# Altium schematic sheet Connectors.SchDoc(Connectors)
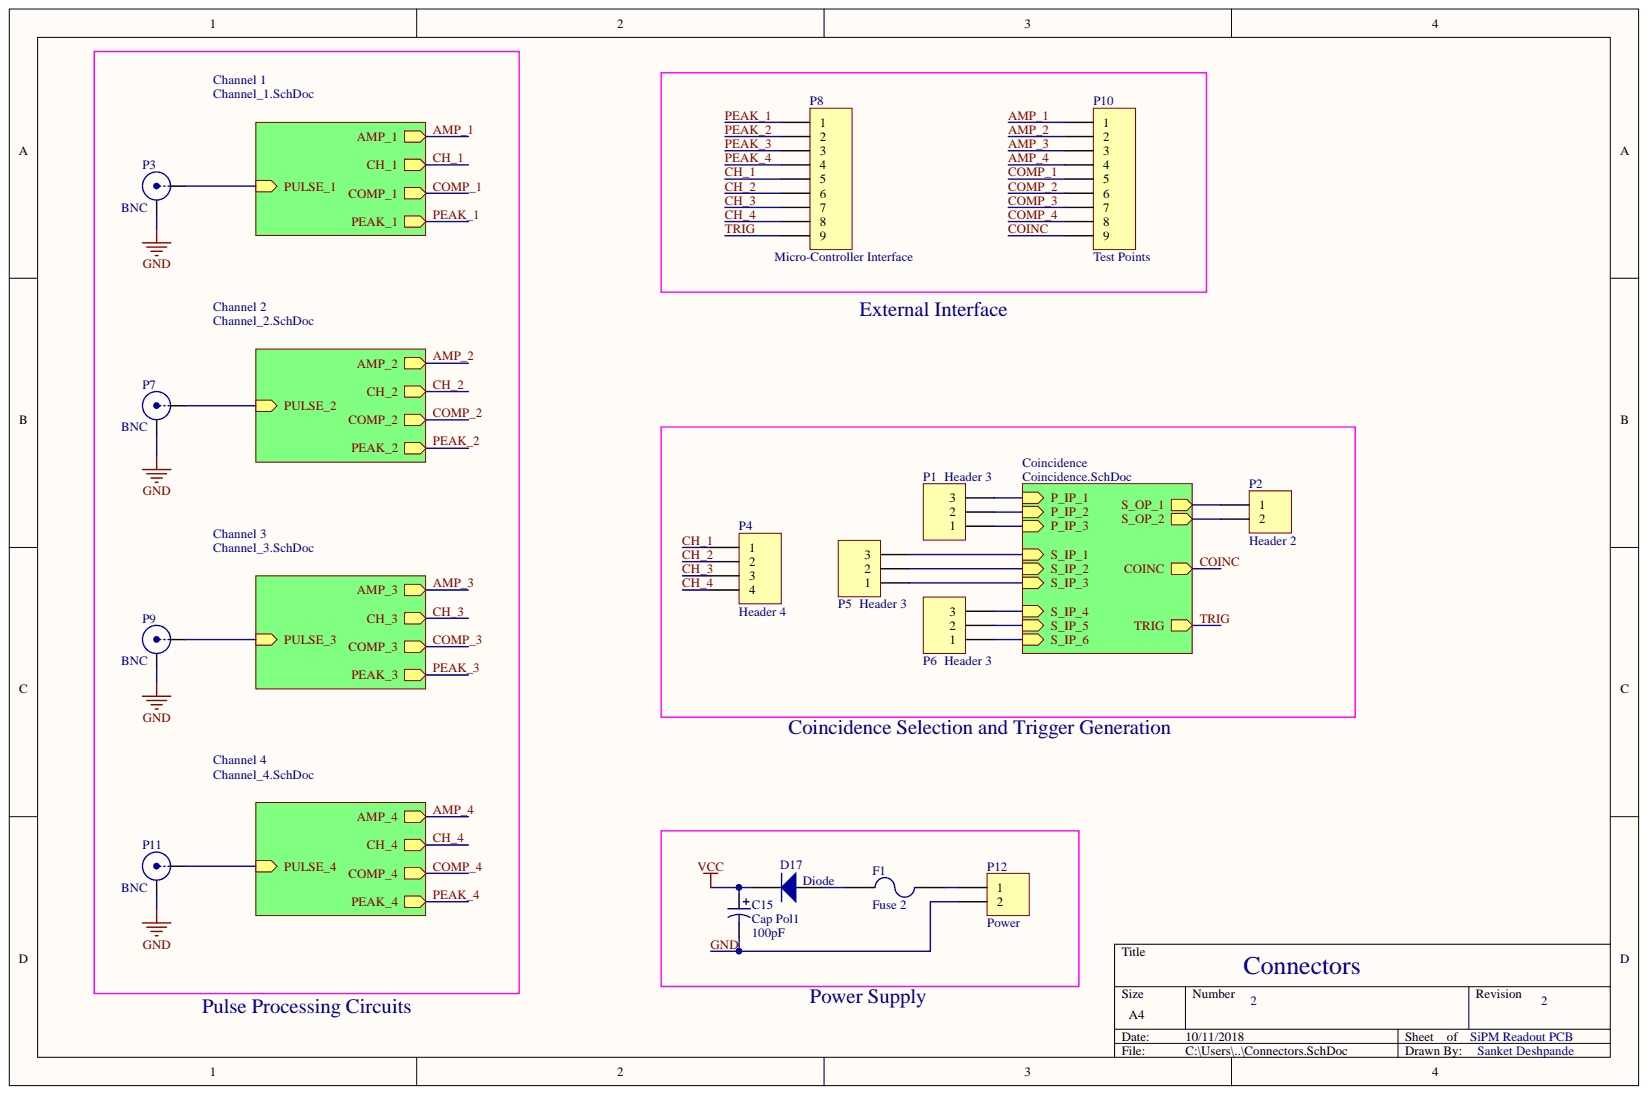
[diagram p0: Connectors.SchDoc]

## Parts
C15  Cap Pol1  value 100pF  [RB7.6-15]
D17  Diode  [SMC]
F1  Fuse 2  [PIN-W2/E2.8]
P1  Header 3  [HDR1X3]
P2  Header 2  [HDR1X2]
P3  BNC  [BNC_RA CON]
P4  Header 4  [HDR1X4]
P5  Header 3  [HDR1X3]
P6  Header 3  [HDR1X3]
P7  BNC  [BNC_RA CON]
P8  Micro-Controller Interface  [HDR1X9]
P9  BNC  [BNC_RA CON]
P10  Test Points  [HDR1X9]
P11  BNC  [BNC_RA CON]
P12  Power  [HDR1X2]

## Nets
VCC: C15.1 D17.2
AMP_1: P10.1
AMP_2: P10.2
AMP_3: P10.3
AMP_4: P10.4
CH_1: P4.1 P8.5
CH_2: P4.2 P8.6
CH_3: P4.3 P8.7
CH_4: P4.4 P8.8
COINC: P10.9
COMP_1: P10.5
COMP_2: P10.6
COMP_3: P10.7
COMP_4: P10.8
GND: C15.2 P3.2 P7.2 P9.2 P11.2 P12.2
PEAK_1: P8.1
PEAK_2: P8.2
PEAK_3: P8.3
PEAK_4: P8.4
TRIG: P8.9
NetD17_1: D17.1 F1.1
NetF1_2: F1.2 P12.1
NetP1_1: P1.1
NetP1_2: P1.2
NetP1_3: P1.3
NetP2_1: P2.1
NetP2_2: P2.2
NetP3_1: P3.1
NetP5_1: P5.1
NetP5_2: P5.2
NetP5_3: P5.3
NetP6_1: P6.1
NetP6_2: P6.2
NetP6_3: P6.3
NetP7_1: P7.1
NetP9_1: P9.1
NetP11_1: P11.1

## Library data of the parts
C15 = Cap Pol1: Polarized Capacitor (Radial); Component Kind: Standard; LatestRevisionDate: 17-Jul-2002; LatestRevisionNote: Re-released for DXP Platform.; Library Name: Miscellaneous Devices.IntLib; PackageDescription: Polarized Capacitor (Radial); 2 Leads; PackageReference: RB7.6-15; Published: 8-Jun-2000; Signal Integrity: Cap Pol; Simulation: CAP; Publisher: Altium Limited
D17 = Diode: Default Diode; Code_JEDEC: DO-214-AB; Component Kind: Standard; LatestRevisionDate: 17-Jul-2002; LatestRevisionNote: Re-released for DXP Platform.; Library Name: Miscellaneous Devices.IntLib; PackageDescription: DO-214-AB/SMC; 2 C-Bend Leads; Body 7.9 x 5.9 mm, inc. leads (LxW); PackageReference: SMC; PackageVersion: Sep-1996; Published: 8-Jun-2000; Signal Integrity: Diode; Simulation: DIODE; Publisher: Altium Limited
F1 = Fuse 2: Fuse; Component Kind: Standard; LatestRevisionDate: 17-Jul-2002; LatestRevisionNote: Re-released for DXP Platform.; Library Name: Miscellaneous Devices.IntLib; PackageDescription: Thru-Hole; 2 Leads; PackageReference: PIN-W2/E2.8; Published: 8-Jun-2000; Simulation: FUSE; Publisher: Altium Limited
P1 P5 P6 = Header 3: Header, 3-Pin; Component Kind: Standard; LatestRevisionDate: 17-Jul-2002; LatestRevisionNote: Re-released for DXP Platform.; Library Name: Miscellaneous Connectors.IntLib; Signal Integrity: Connector; Publisher: Altium Limited
P2 P12 = Header 2: Header, 2-Pin; Component Kind: Standard; LatestRevisionDate: 17-Jul-2002; LatestRevisionNote: Re-released for DXP Platform.; Library Name: Miscellaneous Connectors.IntLib; Signal Integrity: Connector; Publisher: Altium Limited
P3 P7 P9 P11 = BNC: BNC Elbow Connector; Component Kind: Standard; LatestRevisionDate: 17-Jul-2002; LatestRevisionNote: Re-released for DXP Platform.; Library Name: Miscellaneous Connectors.IntLib; Signal Integrity: Connector; Publisher: Altium Limited
P4 = Header 4: Header, 4-Pin; Component Kind: Standard; LatestRevisionDate: 17-Jul-2002; LatestRevisionNote: Re-released for DXP Platform.; Library Name: Miscellaneous Connectors.IntLib; Signal Integrity: Connector; Publisher: Altium Limited
P8 P10 = Header 9: Header, 9-Pin; Component Kind: Standard; LatestRevisionDate: 17-Jul-2002; LatestRevisionNote: Re-released for DXP Platform.; Library Name: Miscellaneous Connectors.IntLib; Signal Integrity: Connector; Publisher: Altium Limited
